# Altium schematic sheet MCU.SchDoc(MCU)
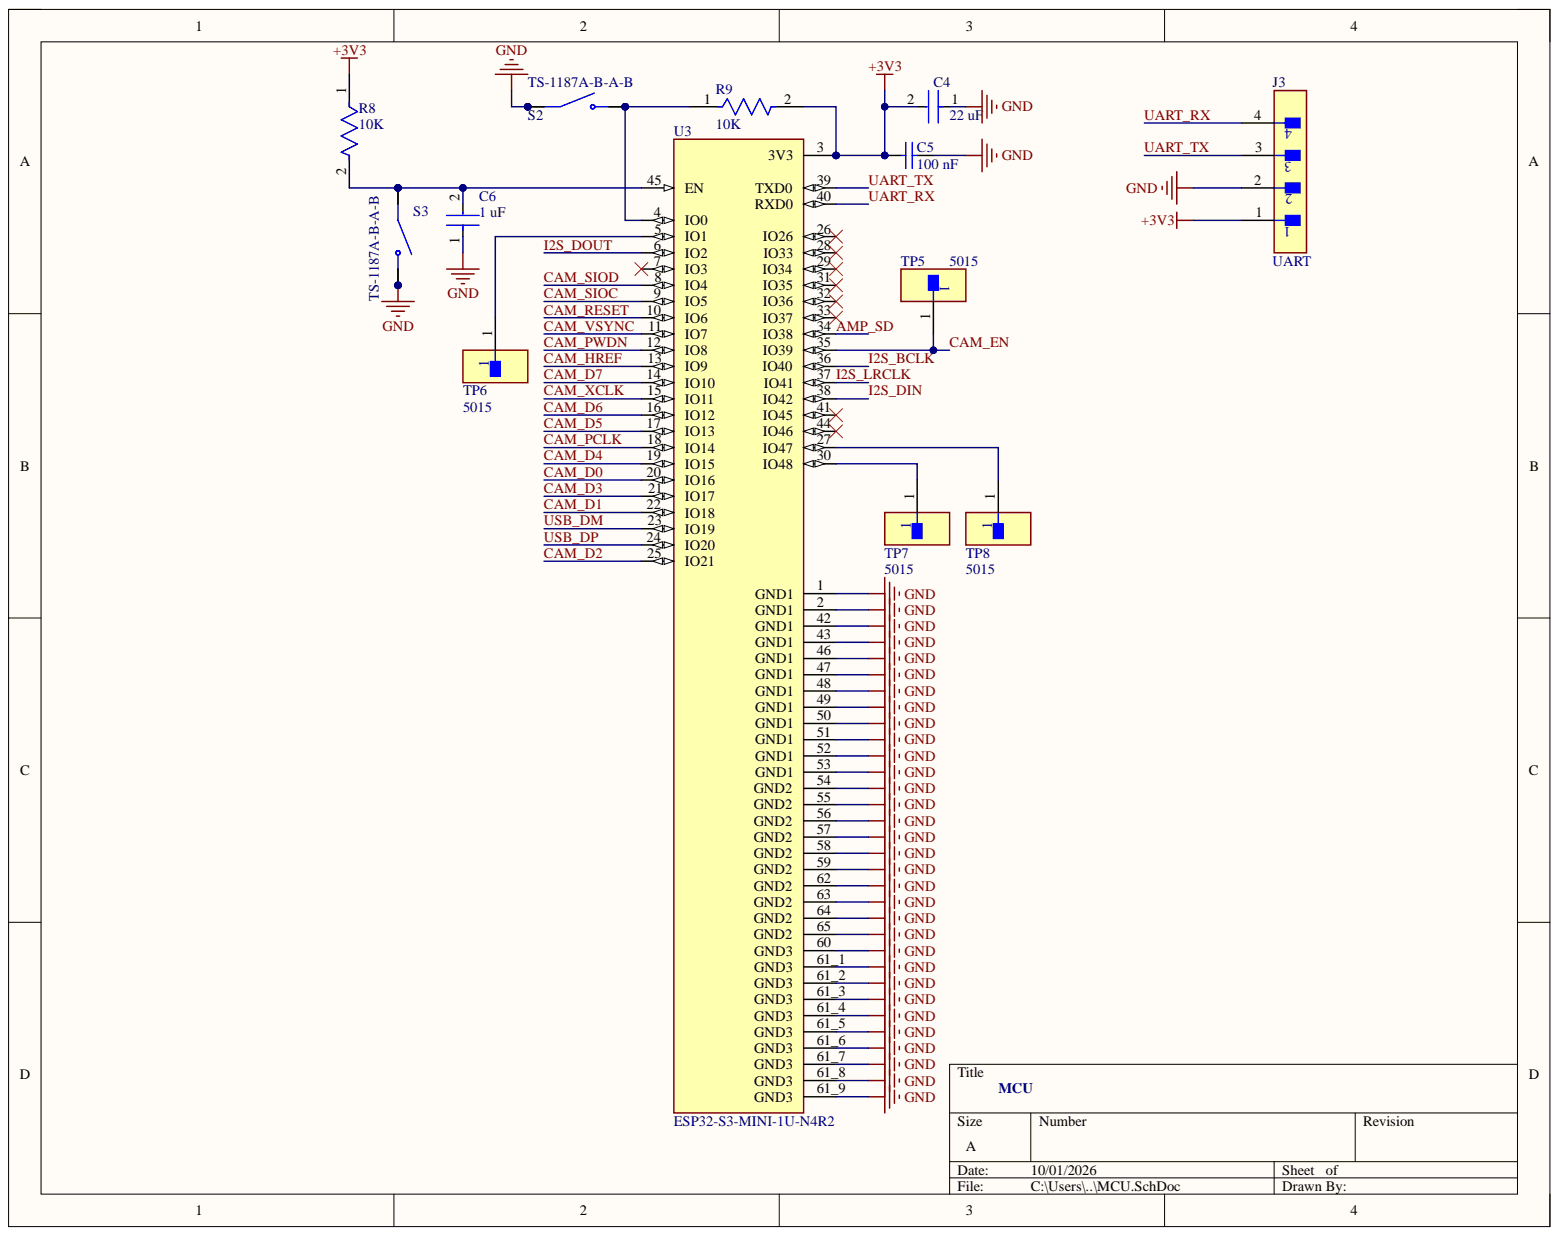
[diagram p0: MCU.SchDoc]

## Parts
C4  22 uF  [FP-CL10-IPC_B]
C5  100 nF  [CAPC0402(1005)60_L]
C6  1 uF  [FP-GRM155-0_05-IPC_A]
J3  UART  [FP-TSW-104-22-G-S-MFG]  (no BOM)
R8  10K  [FP-AC0402-IPC_B]
R9  10K  [FP-AC0402-IPC_B]
S2  TS-1187A-B-A-B  [SW_TS-1187A-B-A-B]
S3  TS-1187A-B-A-B  [SW_TS-1187A-B-A-B]
TP5  5015  [FP-5015-MFG]  (no BOM)
TP6  5015  [FP-5015-MFG]  (no BOM)
TP7  5015  [FP-5015-MFG]  (no BOM)
TP8  5015  [FP-5015-MFG]  (no BOM)
U3  ESP32-S3-MINI-1U-N4R2  [XCVR_ESP32-S3-MINI-1U-N8]

## Nets
+3V3: C4.2 C5.1 J3.1 R8.1 R9.2 U3.3(3V3)
GND: C4.1 C5.2 C6.1 J3.2 S2.A S2.B S3.C S3.D U3.1(GND1) U3.2(GND1) U3.42(GND1) U3.43(GND1) U3.46(GND1) U3.47(GND1) U3.48(GND1) U3.49(GND1) U3.50(GND1) U3.51(GND1) U3.52(GND1) U3.53(GND1) U3.54(GND2) U3.55(GND2) U3.56(GND2) U3.57(GND2) U3.58(GND2) U3.59(GND2) U3.60(GND3) U3.61_1(GND3) U3.61_2(GND3) U3.61_3(GND3) U3.61_4(GND3) U3.61_5(GND3) U3.61_6(GND3) U3.61_7(GND3) U3.61_8(GND3) U3.61_9(GND3) U3.62(GND2) U3.63(GND2) U3.64(GND2) U3.65(GND2)
AMP_SD: U3.34(IO38)
CAM_D0: U3.20(IO16)
CAM_D1: U3.22(IO18)
CAM_D2: U3.25(IO21)
CAM_D3: U3.21(IO17)
CAM_D4: U3.19(IO15)
CAM_D5: U3.17(IO13)
CAM_D6: U3.16(IO12)
CAM_D7: U3.14(IO10)
CAM_EN: TP5.1 U3.35(IO39)
CAM_HREF: U3.13(IO9)
CAM_PCLK: U3.18(IO14)
CAM_PWDN: U3.12(IO8)
CAM_RESET: U3.10(IO6)
CAM_SIOC: U3.9(IO5)
CAM_SIOD: U3.8(IO4)
CAM_VSYNC: U3.11(IO7)
CAM_XCLK: U3.15(IO11)
I2S_BCLK: U3.36(IO40)
I2S_DIN: U3.38(IO42)
I2S_DOUT: U3.6(IO2)
I2S_LRCLK: U3.37(IO41)
UART_RX: J3.4 U3.40(RXD0)
UART_TX: J3.3 U3.39(TXD0)
USB_DM: U3.23(IO19)
USB_DP: U3.24(IO20)
NetC6_2: C6.2 R8.2 S3.A S3.B U3.45(EN)
NetR9_1: R9.1 S2.C S2.D U3.4(IO0)
NetTP6_1: TP6.1 U3.5(IO1)
NetTP7_1: TP7.1 U3.30(IO48)
NetTP8_1: TP8.1 U3.27(IO47)

Not connected: U3 7(IO3) 26(IO26) 28(IO33) 29(IO34) 31(IO35) 32(IO36) 33(IO37) 41(IO45) 44(IO46)

## Library data of the parts
C4 = CMP-13271-002500-1: Cap Ceramic 22uF 6.3V X5R ±20% Pad SMD 0603 +85°C T/R; Component Kind: Standard; Library Name: Altium Content Vault
C5 = CMP-1034-02073-1: CAP 100nF 25V ±20% 0402 (1005 Metric) Thickness 0.6mm SMD; Case-EIA: 0402; Case-Metric: 1005; Tolerance: Â±20%; Component Kind: Standard; Library Name: Altium Content Vault; Max Thickness: 0.6 mm; Rated Voltage: 25 V; Technology: SMT; Value: 100nF
C6 = CMP-06035-052171-1: Chip Multilayer Ceramic Capacitors for General Purpose, 0402, 1.0uF, X6S, 22%, 10%, 10V; Capacitance: 1uF; Case/Package: 0402; Component Kind: Standard; Dielectric: X6S; Length: 0.039inch; Library Name: Altium Content Vault; Max Operating Temperature: 105°C; Min Operating Temperature: -55°C; Mount: Surface Mount; Package Quantity: 50000; Packaging: Tape and Reel; Thickness: 0.022inch; Tolerance: 10%; Voltage Rating: 10V; Voltage Rating (DC): 10V; Weight: 0.000212oz; Width: 0.02inch
J3 = CMP-02766-001236-1: CONN HEADER VERT 4POS 2.54MM; Gender: Male; Number of Contacts: 4; Contact Material: Bronze; Contact Plating: Gold; Library Name: Altium Content Vault; Mount: Through Hole; Number of Rows: 1; Packaging: Bulk; Pitch: 2.54mm; Radiation Hardening: No; REACH SVHC: No SVHC; Termination: Solder
R8 R9 = CMP-03412-022979-2: RES 10kΩ ±1% 0.063W 0402; Resistance: 10kR; Case/Package: 0402; Component Kind: Standard; Library Name: Altium Content Vault; RoHS Compliant: Yes; Tolerance: 1%
S2 S3 = CMP-007-000000-1: SPST 50mA @ 12VDC Top Actuated Round Button 160gf SMD Tactile Switches RoHS; Availability: Unavailable; Component Kind: Standard; Component Type: Standard; Design Item ID: TS-1187A-B-A-B; MP: TS-1187A-B-A-B; Package: None; Pin Count: 4; Price: None; Source: TS-1187A-B-A-B.SchLib; Library Name: AI-Glasses
TP5-TP8 = CMP-2000-05183-2: PC TEST POINT MINIATURE; Manufacturer: keystone; Conn Orientation: Straight; Number Of Contacts: 1; Automotive: No; Category: Conn; ComponentLink2Description: Datasheet; ComponentLink2URL: http://www.keyelco.com/product-pdf.cfm?p=1353; Contact Material: Silver; Device Class L1: Connectors; Device Class L2: unset; Device Class L3: unset; Digikey Description: PC TEST POINT MINIATURE; Height: 1.65mm; Lead Free: Yes; Library Name: Altium Content Vault; Manufacturer Part Number: 5015; Octopart: https://octopart.com/5015-keystone-3822; Package: 5015; RoHS: TRUE
U3 = CMP-005-000000-1: Bluetooth, WiFi 802.11b/g/n, Bluetooth v5.0 Transceiver Module 2.4GHz Antenna Not Included, U.FL Surface Mount; MF: Espressif Systems; Check_prices: https://www.snapeda.com/parts/ESP32-S3-MINI-1U-N8/Espressif+Systems/view-part/?ref=eda; Component Kind: Standard; Component Type: Standard; Design Item ID: ESP32-S3-MINI-1U-N8; MP: ESP32-S3-MINI-1U-N4R2; Package: VFQFN-56 Espressif Systems; Pin Count: 73; SnapEDA_Link: https://www.snapeda.com/parts/ESP32-S3-MINI-1U-N8/Espressif+Systems/view-part/?ref=snap; Source: ESP32-S3-MINI-1U-N8.SchLib; Library Name: AI-Glasses
